# Altium schematic sheet TGA3042.SchDoc
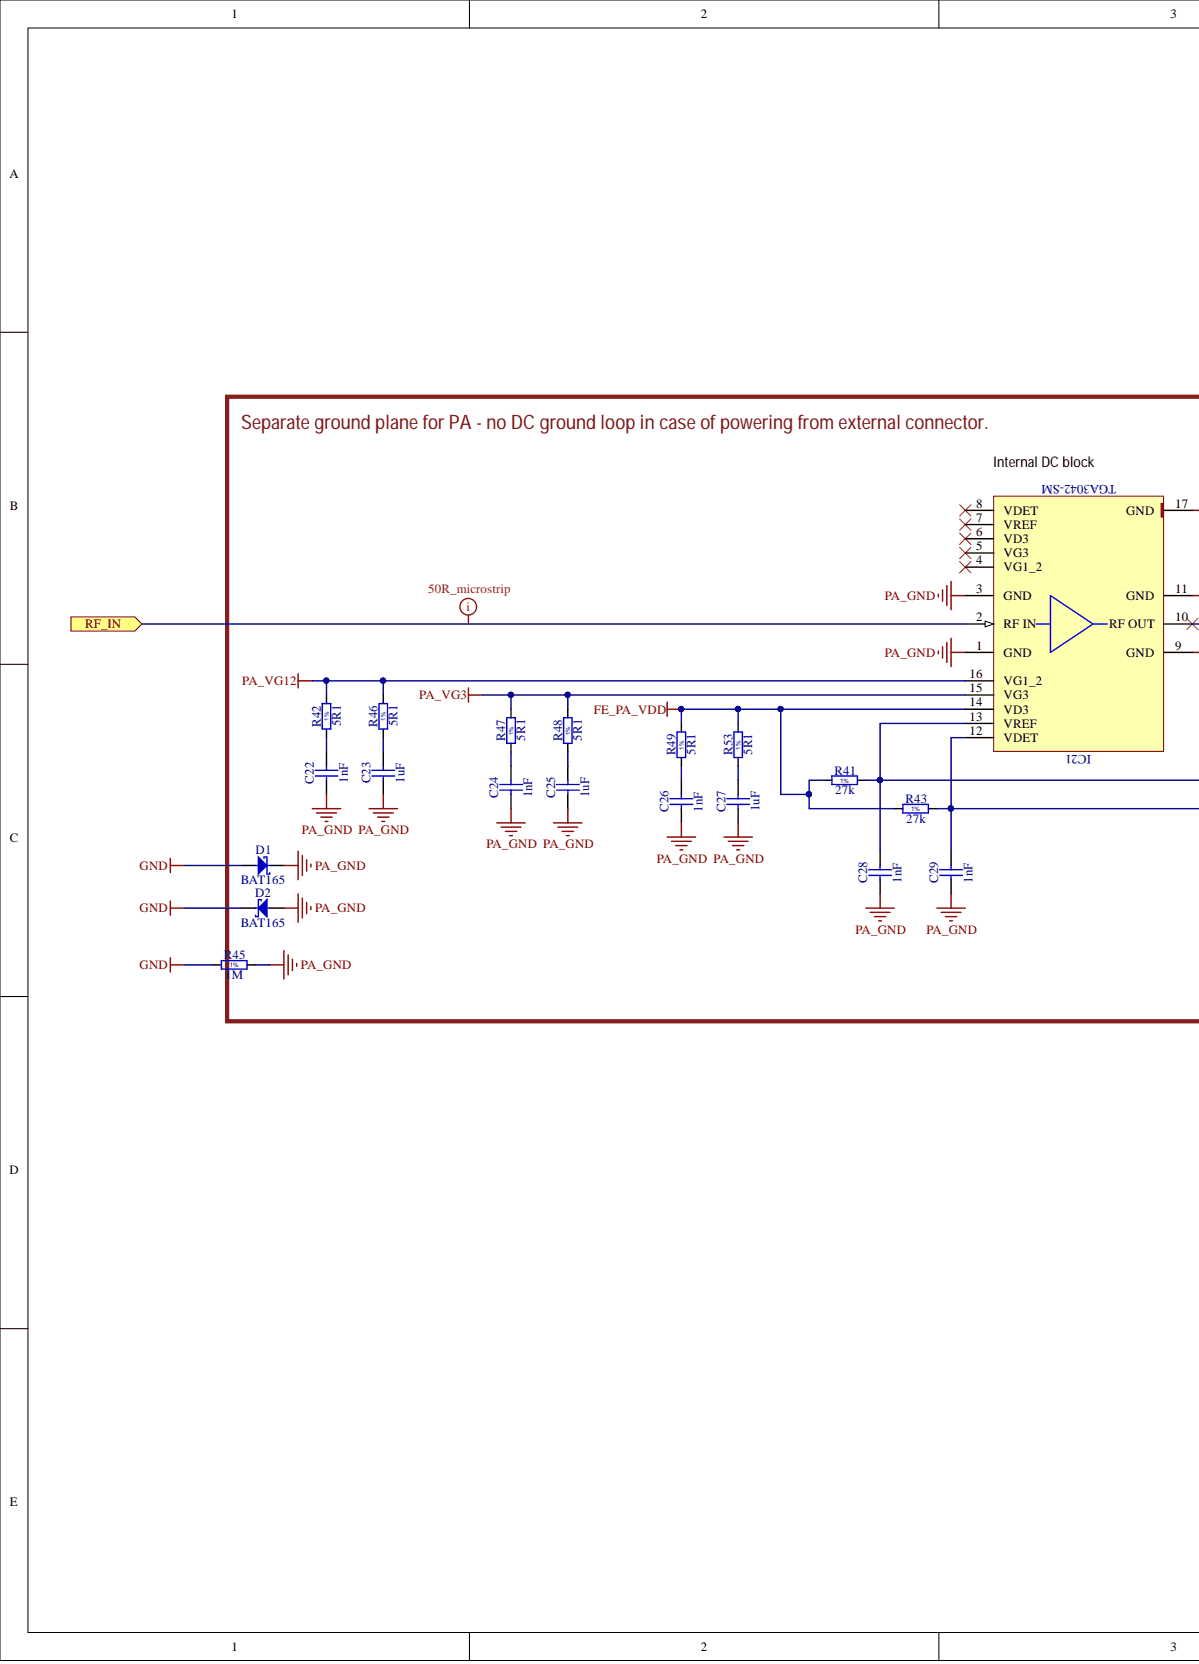
[diagram p0: TGA3042.SchDoc, left]
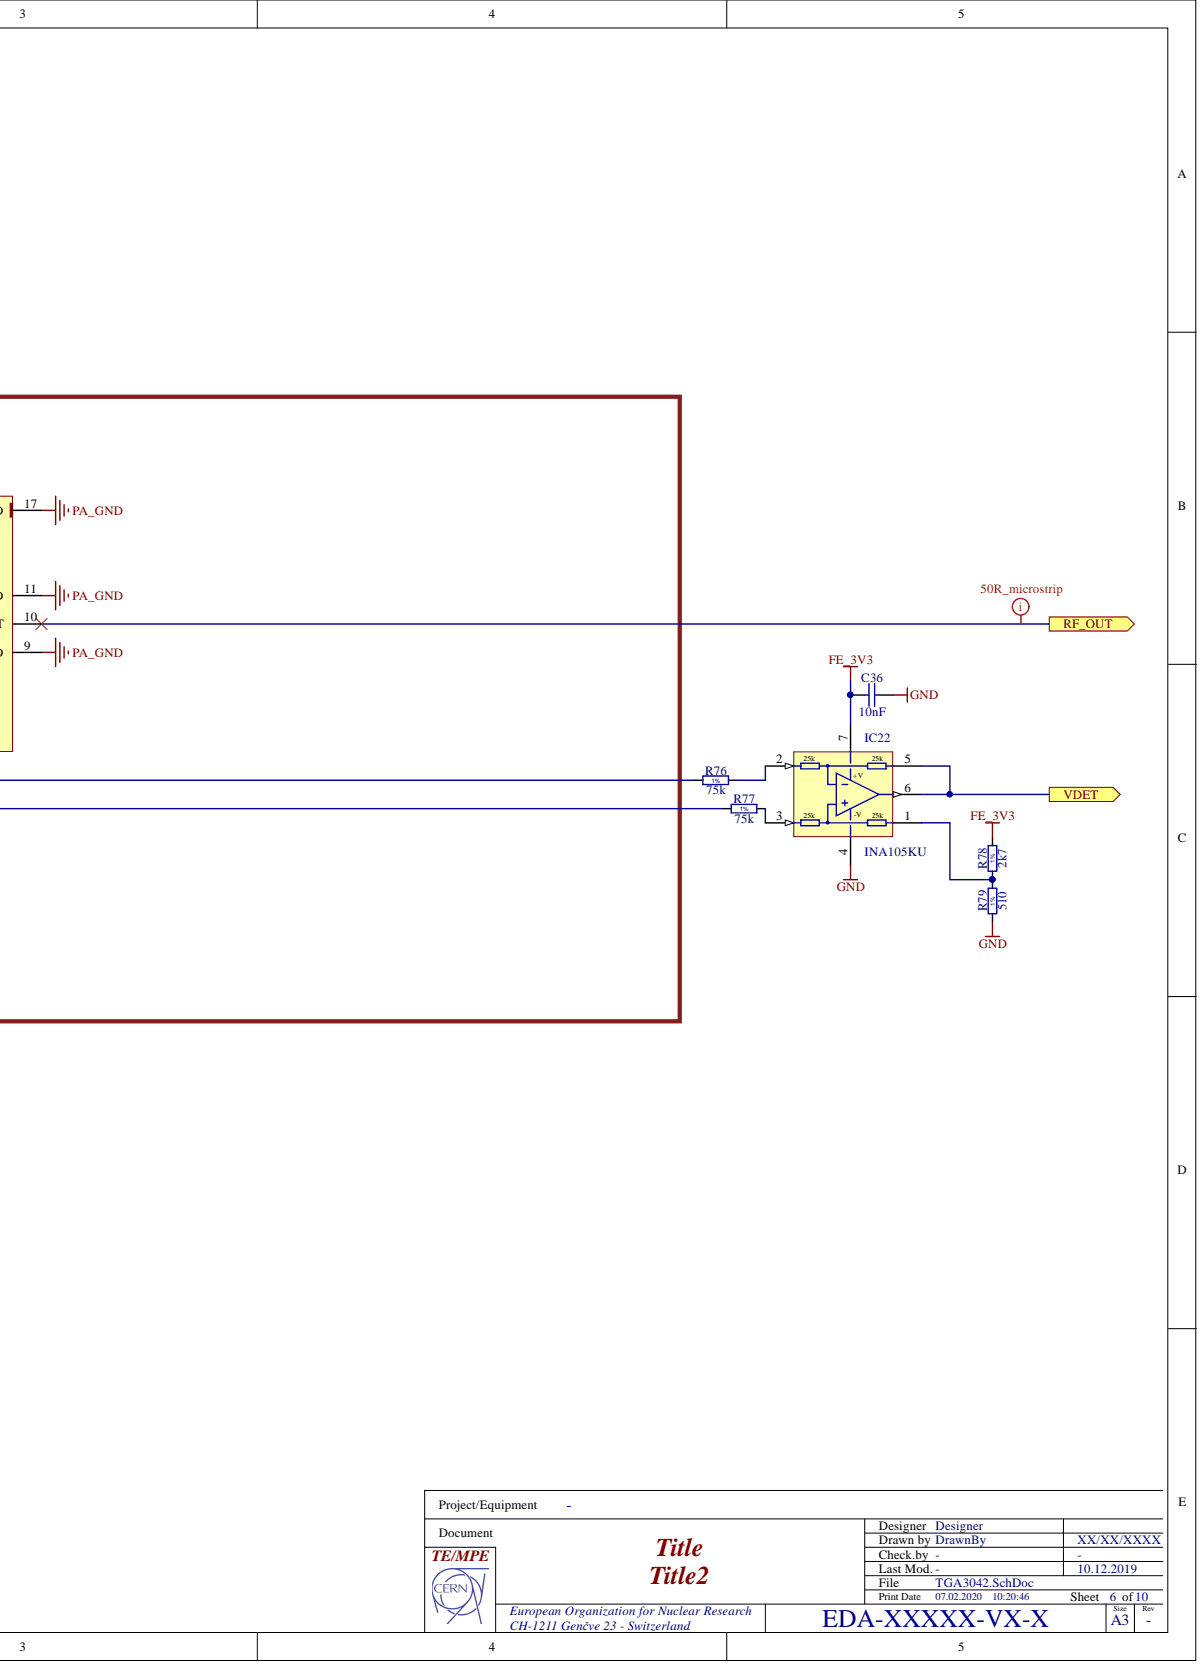
[diagram p1: TGA3042.SchDoc, right]

## Parts
C22  1nF  [CAPC1005X55N]
C23  1uF  [CAPC3216X140N]
C24  1nF  [CAPC1005X55N]
C25  1uF  [CAPC3216X140N]
C26  1nF  [CAPC1005X55N]
C27  1uF  [CAPC3216X140N]
C28  1nF  [CAPC1005X55N]
C29  1nF  [CAPC1005X55N]
C36  10nF  [CAPC1005X55N]
D1  BAT165  [SOD2512X110N]
D2  BAT165  [SOD2512X110N]
IC21  TGA3042-SM  [QORVO_TGA3042-SM]
IC22  INA105KU  [SOIC127P600X175-8N]
R41  27k  [RESC1005X40N]
R42  5R1  [RESC1005X40N]
R43  27k  [RESC1005X40N]
R45  1M  [RESC1005X40N]
R46  5R1  [RESC1005X40N]
R47  5R1  [RESC1005X40N]
R48  5R1  [RESC1005X40N]
R49  5R1  [RESC1005X40N]
R53  5R1  [RESC1005X40N]
R76  75k  [RESC1608X55N]
R77  75k  [RESC1608X55N]
R78  2k7  [RESC1608X55N]
R79  510  [RESC1608X55N]

## Ports
RF_IN, RF_OUT, VDET

## Nets
FE_3V3: C36.1 IC22.7 R78.2
FE_PA_VDD: IC21.14(VD3) R41.1 R43.1 R49.2 R53.2
GND: C36.2 D1.2 D2.1 IC22.4 R45.1 R79.1
PA_GND: C22.1 C23.1 C24.1 C25.1 C26.1 C27.1 C28.1 C29.1 D1.1 D2.2 IC21.1(GND) IC21.3(GND) IC21.9(GND) IC21.11(GND) IC21.17(GND) R45.2
PA_VG3: IC21.15(VG3) R47.2 R48.2
PA_VG12: IC21.16(VG1_2) R42.2 R46.2
RF_IN: IC21.2(RF_IN) ; port RF_IN
RF_OUT: IC21.10(RF_OUT) ; port RF_OUT
VDET: IC22.5 IC22.6 ; port VDET
NetC22_2: C22.2 R42.1
NetC23_2: C23.2 R46.1
NetC24_2: C24.2 R47.1
NetC25_2: C25.2 R48.1
NetC26_2: C26.2 R49.1
NetC27_2: C27.2 R53.1
NetC28_2: C28.2 IC21.13(VREF) R41.2 R76.1
NetC29_2: C29.2 IC21.12(VDET) R43.2 R77.1
NetIC22_1: IC22.1 R78.1 R79.2
NetIC22_2: IC22.2 R76.2
NetIC22_3: IC22.3 R77.2

Not connected: IC21 4(VG1_2) 5(VG3) 6(VD3) 7(VREF) 8(VDET)

## Library data of the parts
C22 C24 C26 C28 C29 = CC0402_1NF_50V_10%_X7R: Non-polarised capacitor; Manufacturer: GENERIC; Manufacturer1 Part Number: 223858715623; Part Description: SMD Multilayer Chip Ceramic Capacitor; Database Table Name: Capacitors SMD; TC: X7R; Tolerance: ±10%; Voltage: 50V; Case: 0402; Component Kind: Standard; Component Type: Standard; CreateDate: 03.12.2007; HelpURL: \\cern.ch\dfs\Applications\Altium\Datasheets\CC0402_X7R_PHYCOMP.pdf; LatestRevisionDate: 03.12.2007; Library Name: Capacitors.DbLib; Manufacturer1 ComponentHeight: 0.55mm; Manufacturer1 Example: PHYCOMP; Manufacturer Part Number: CC0402_1NF_50V_10%_X7R; Mounted: Yes; Part Number: CC0402_1NF_50V_10%_X7R; Pin Count: 2; Sense: No; SMD: Yes; Socket: No; Status: Not Recommended; Value: 1nF; Author: CERN DEM JLC
C23 C25 C27 = CC1206_1UF_50V_10%_X7R: Non-polarised capacitor; Manufacturer: GENERIC; Manufacturer1 Part Number: C1206F105K5RACTU; Part Description: SMD Multilayer Chip Ceramic Capacitor; Database Table Name: Capacitors SMD; TC: X7R; Tolerance: ±10%; Voltage: 50V; Case: 1206; Component Kind: Standard; Component Type: Standard; CreateDate: 07.11.2012; HelpURL: \\cern.ch\dfs\Applications\Altium\Datasheets\CC1206_X7R_KEMET_OPENMODE.pdf; LatestRevisionDate: 07.11.2012; Library Name: Capacitors.DbLib; Manufacturer1 ComponentHeight: 1.8mm; Manufacturer1 Example: KEMET; Manufacturer Part Number: CC1206_1UF_50V_10%_X7R; Mounted: Yes; Part Number: CC1206_1UF_50V_10%_X7R; Pin Count: 2; Sense: No; SMD: Yes; Socket: No; Status: Preferred; Value: 1uF; Author: CERN DEM JLC
C36 = CC0402_10NF_50V_10%_X7R: Non-polarised capacitor; Manufacturer: GENERIC; Manufacturer1 Part Number: GRM155R71H103KA88D; Part Description: SMD Multilayer Chip Ceramic Capacitor; Database Table Name: Capacitors SMD; TC: X7R; Tolerance: ±10%; Voltage: 50V; Case: 0402; Component Kind: Standard; Component Type: Standard; CreateDate: 08.01.2016; HelpURL: \\cern.ch\dfs\Applications\Altium\Datasheets\CC0402_X7R_MURATA_GRM.pdf; LatestRevisionDate: 08.01.2016; Library Name: Capacitors.DbLib; Manufacturer1 ComponentHeight: 0.55mm; Manufacturer1 Example: MURATA; Manufacturer Part Number: CC0402_10NF_50V_10%_X7R; Mounted: Yes; Part Number: CC0402_10NF_50V_10%_X7R; Pin Count: 2; Sense: No; SMD: Yes; Socket: No; Status: None; Value: 10nF; Author: CERN DEM MR
D1 D2 = BAT165: Schottky Diode; Manufacturer: INFINEON; Part Description: Medium Power AF Schottky Diode; Bonding: No; Database Table Name: Diodes; Power: 750mA; PressFit: No; Voltage: 40V; Case: SOD323; ComponentHeight: 1.1mm; Component Kind: Standard; Component Type: Standard; CreateDate: 12.11.2014; Device: BAT165; HelpURL: \\cern.ch\dfs\Applications\Altium\Datasheets\BAT165.pdf; LatestRevisionDate: 12.11.2014; Library Name: ICs And Semiconductors.DbLib; Manufacturer Part Number: BAT165; Mounted: Yes; PackageDescription: Small Outline Diode (SOD-323), 1.70mm W X 1.25mm L X 1.10mm H body,  IPC Medium Density; Part Number: BAT165; Pin Count: 2; Sense: No; SMD: Yes; Socket: No; Author: CERN DEM JLC
IC21 = (no symbol name): 7-10.5GHz 4.5W GaN Power Amplifier; Manufacturer: QORVO; Bonding: No; PressFit: No; Case: QFN16; ComponentHeight: 1.91mm; Component Kind: Standard; Component Type: Standard; CreateDate: 26.03.2019; Device: TGA3042-SM; HelpURL: \\cern.ch\dfs\Applications\Altium\Datasheets\TGA3042-SM.pdf; LatestRevisionDate: 26.03.2019; Manufacturer Part Number: TGA3042-SM; Mounted: Yes; PackageDescription: QFN, 0.566mm Pitch X, 0.525 Pitch Y, Square; 16 Pin, 4.5mm L X 4.5mm W X 1.74mm H Body; Part Description: 7-10.5GHz 4.5W GaN Power Amplifier; Part Number: TGA3042-SM; Pin Count: 17; Sense: No; SMD: Yes; Socket: No; Author: CERN DEM JLC; Library Name: FMC_SDR.SchLib
IC22 = INA105KU: Precision, Unity-Gain Differential Amplifier; Manufacturer: TEXAS INSTRUMENTS; Bonding: No; Database Table Name: Operational Amplifiers; PressFit: No; Case: SOIC8; ComponentHeight: 1.75mm; Component Kind: Standard; Component Type: Standard; CreateDate: 07.01.2008; Device: INA105KU; HelpURL: \\cern.ch\dfs\Applications\Altium\Datasheets\INA105KU.pdf; LatestRevisionDate: 07.01.2008; Library Name: ICs And Semiconductors.DbLib; Manufacturer Part Number: INA105KU; Mounted: Yes; PackageDescription: SOIC 8, Pitch 1.27mm, Body 4x5mm, IPC Medium Density; Part Description: Precision, Unity-Gain Differential Amplifier; Part Number: INA105KU; Pin Count: 7; Sense: No; SMD: Yes; Socket: No; Author: CERN DEM JLC
R41 R43 = R0402_27K_1%_0.0625W_100PPM: Resistor - 1%; Manufacturer: GENERIC; Manufacturer1 Part Number: 232270672703L; Part Description: General Purpose Thick Film Chip Resistor; Database Table Name: Resistors SMD; PressFit: No; TC: ±100ppm/°C; Case: 0402; Component Kind: Standard; Component Type: Standard; CreateDate: 03.12.2007; HelpURL: \\cern.ch\dfs\Applications\Altium\Datasheets\R0402_Phycomp_RC0402.pdf; LatestRevisionDate: 17.10.2012; Library Name: Resistors.DbLib; Manufacturer1 ComponentHeight: 0.4mm; Manufacturer1 Example: YAGEO PHYCOMP; Manufacturer Part Number: R0402_27K_1%_0.0625W_100PPM; Mounted: Yes; Part Number: R0402_27K_1%_0.0625W_100PPM; Pin Count: 2; Power: 0.0625W; Sense: No; SMD: Yes; Socket: No; Status: Not Recommended; Tolerance: ±1%; Value: 27k; Author: CERN DEM JLC
R42 R46-R49 R53 = R0402_5R1_1%_0.0625W_100PPM: Resistor - 1%; Manufacturer: GENERIC; Manufacturer1 Part Number: CRCW04025R10FKED; Part Description: General Purpose Thick Film Chip Resistor; Database Table Name: Resistors SMD; PressFit: No; TC: ±100ppm/°C; Case: 0402; Component Kind: Standard; Component Type: Standard; CreateDate: 22.11.2016; HelpURL: \\cern.ch\dfs\Applications\Altium\Datasheets\R0402_VISHAY_CRCW e3.pdf; LatestRevisionDate: 22.11.2016; Library Name: Resistors.DbLib; Manufacturer1 ComponentHeight: 0.4mm; Manufacturer1 Example: VISHAY; Manufacturer Part Number: R0402_5R1_1%_0.0625W_100PPM; Mounted: Yes; Part Number: R0402_5R1_1%_0.0625W_100PPM; Pin Count: 2; Power: 0.0625W; Sense: No; SMD: Yes; Socket: No; Status: Not Recommended; Tolerance: ±1%; Value: 5R1; Author: CERN DEM MR
R45 = R0402_1M_1%_0.0625W_100PPM: Resistor - 1%; Manufacturer: GENERIC; Manufacturer1 Part Number: 232270671005L; Part Description: General Purpose Thick Film Chip Resistor; Database Table Name: Resistors SMD; PressFit: No; TC: ±100ppm/°C; Case: 0402; Component Kind: Standard; Component Type: Standard; CreateDate: 03.12.2007; HelpURL: \\cern.ch\dfs\Applications\Altium\Datasheets\R0402_Phycomp_RC0402.pdf; LatestRevisionDate: 17.10.2012; Library Name: Resistors.DbLib; Manufacturer1 ComponentHeight: 0.4mm; Manufacturer1 Example: YAGEO PHYCOMP; Manufacturer Part Number: R0402_1M_1%_0.0625W_100PPM; Mounted: Yes; Part Number: R0402_1M_1%_0.0625W_100PPM; Pin Count: 2; Power: 0.0625W; Sense: No; SMD: Yes; Socket: No; Status: Not Recommended; Tolerance: ±1%; Value: 1M; Author: CERN DEM JLC
R76 R77 = R0603_75K_1%_0.1W_100PPM: Resistor - 1%; Manufacturer: GENERIC; Manufacturer1 Part Number: NRC06F7502TRF; Part Description: General Purpose Thick Film Chip Resistor; Database Table Name: Resistors SMD; Power: 0.1W; PressFit: No; TC: ±100ppm/°C; Case: 0603; Component Kind: Standard; Component Type: Standard; CreateDate: 03.12.2007; HelpURL: \\cern.ch\dfs\Applications\Altium\Datasheets\R0603_NIC_NRC.pdf; LatestRevisionDate: 13.03.2008; Library Name: Resistors.DbLib; Manufacturer1 ComponentHeight: 0.55mm; Manufacturer1 Example: NIC COMPONENT; Manufacturer Part Number: R0603_75K_1%_0.1W_100PPM; Mounted: Yes; Part Number: R0603_75K_1%_0.1W_100PPM; Pin Count: 2; Sense: No; SMD: Yes; Socket: No; Status: Preferred; Tolerance: ±1%; Value: 75k; Author: CERN DEM JLC
R78 = R0603_2K7_1%_0.1W_100PPM: Resistor - 1%; Manufacturer: GENERIC; Manufacturer1 Part Number: RC0603FR-072K7L; Part Description: General Purpose Thick Film Chip Resistor; Database Table Name: Resistors SMD; Power: 0.1W; PressFit: No; TC: ±100ppm/°C; Case: 0603; Component Kind: Standard; Component Type: Standard; CreateDate: 02.05.2011; HelpURL: \\cern.ch\dfs\Applications\Altium\Datasheets\R0603_PHYCOMP_RC0603.pdf; LatestRevisionDate: 02.05.2011; Library Name: Resistors.DbLib; Manufacturer1 ComponentHeight: 0.55mm; Manufacturer1 Example: YAGEO PHYCOMP; Manufacturer Part Number: R0603_2K7_1%_0.1W_100PPM; Mounted: Yes; Part Number: R0603_2K7_1%_0.1W_100PPM; Pin Count: 2; Sense: No; SMD: Yes; Socket: No; Status: Preferred; Tolerance: ±1%; Value: 2k7; Author: CERN DEM JLC
R79 = R0603_510R_1%_0.1W_100PPM: Resistor - 1%; Manufacturer: GENERIC; Manufacturer1 Part Number: CRCW0603510RFKEA; Part Description: General Purpose Thick Film Chip Resistor; Database Table Name: Resistors SMD; Power: 0.1W; PressFit: No; TC: ±100ppm/°C; Case: 0603; Component Kind: Standard; Component Type: Standard; CreateDate: 03.12.2007; HelpURL: \\cern.ch\dfs\Applications\Altium\Datasheets\R0603_VISHAY_CRCW e3.pdf; LatestRevisionDate: 21.03.2018; Library Name: Resistors.DbLib; Manufacturer1 ComponentHeight: 0.5mm; Manufacturer1 Example: VISHAY; Manufacturer Part Number: R0603_510R_1%_0.1W_100PPM; Mounted: Yes; Part Number: R0603_510R_1%_0.1W_100PPM; Pin Count: 2; Sense: No; SMD: Yes; Socket: No; Status: Preferred; Tolerance: ±1%; Value: 510; Author: CERN DEM JLC
